# Altium schematic sheet DMotor_Schematic_page2.SchDoc
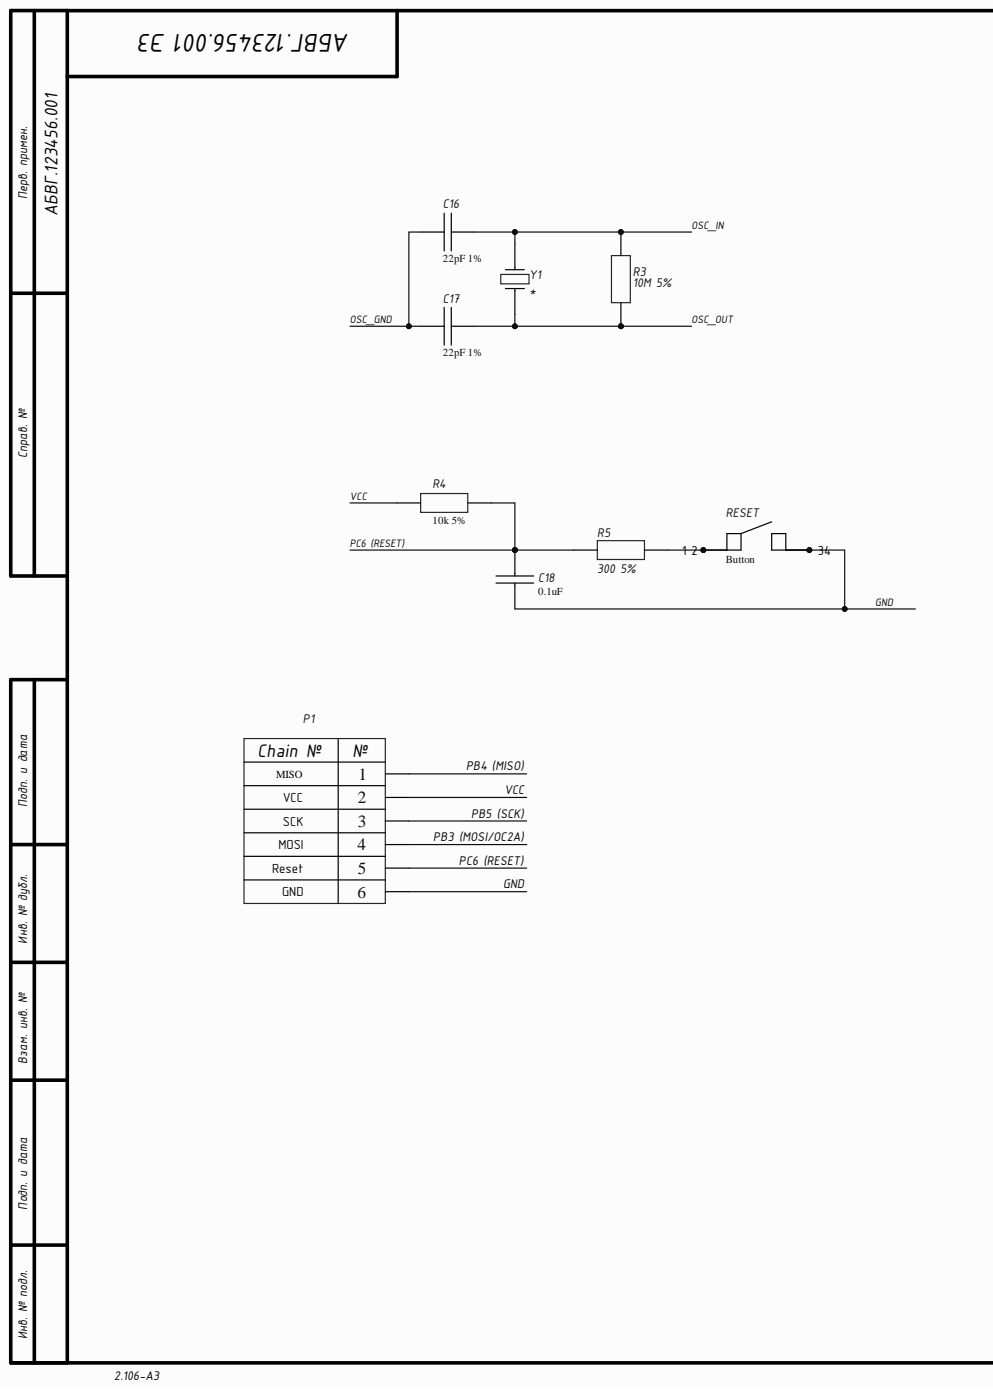
[diagram p0: DMotor_Schematic_page2.SchDoc, left]
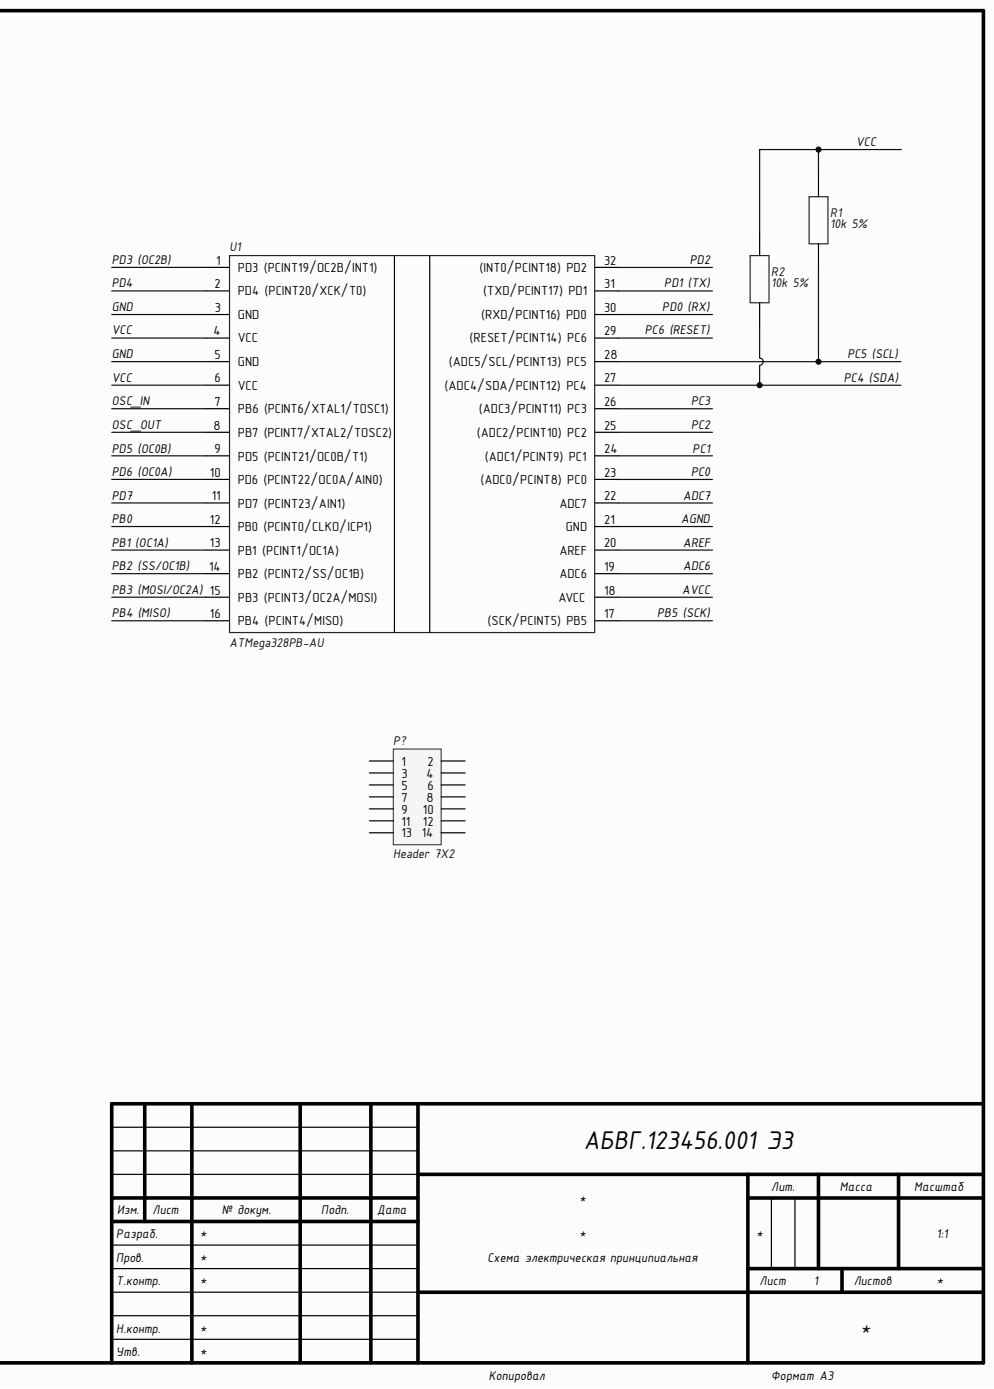
[diagram p1: DMotor_Schematic_page2.SchDoc, right]

## Parts
C16  22pF 1%  [C0603]
C17  22pF 1%  [C0603]
C18  0.1uF  [C0603]
P1  ICSP  [2x3 Male Header Through Hole Pitch 2.54 mm]  (value not drawn)
P?  Header 7X2  [HDR2X7]
R1  10k 5%  [R0603]
R2  10k 5%  [R0603]
R3  10M 5%  [R0603]
R4  10k 5%  [R0603]
R5  300 5%  [R0603]
RESET  Button  [KMR221GLFS]
U1  ATMega328PB-AU  [TQFP-32 (7x7)]
Y1  (no value)  [HC-49S]

## Nets
ADC6: U1.19(ADC6)
ADC7: U1.22(ADC7)
AGND: U1.21(GND)
AREF: U1.20(AREF)
AVCC: U1.18(AVCC)
GND: C18.2 P1.6 RESET.3 RESET.4 U1.3(GND) U1.5(GND)
OSC_GND: C16.1 C17.2
OSC_IN: C16.2 R3.2 U1.7(PB6) Y1.2
OSC_OUT: C17.1 R3.1 U1.8(PB7) Y1.1
PB0: U1.12(PB0)
PB1 (OC1A): U1.13(PB1)
PB2 (SS/OC1B): U1.14(PB2)
PB3 (MOSI/OC2A): P1.4 U1.15(PB3)
PB4 (MISO): P1.1 U1.16(PB4)
PB5 (SCK): P1.3 U1.17(PB5)
PC0: U1.23(PC0)
PC1: U1.24(PC1)
PC2: U1.25(PC2)
PC3: U1.26(PC3)
PC4 (SDA): R2.1 U1.27(PC4)
PC5 (SCL): R1.1 U1.28(PC5)
PC6 (RESET): C18.1 P1.5 R4.2 R5.1 U1.29(PC6)
PD0 (RX): U1.30(PD0)
PD1 (TX): U1.31(PD1)
PD2: U1.32(PD2)
PD3 (OC2B): U1.1(PD3)
PD4: U1.2(PD4)
PD5 (OC0B): U1.9(PD5)
PD6 (OC0A): U1.10(PD6)
PD7: U1.11(PD7)
VCC: P1.2 R1.2 R2.2 R4.1 U1.4(VCC) U1.6(VCC)
NetR5_2: R5.2 RESET.1 RESET.2

Not connected: P? 1 2 3 4 5 6 7 8 9 10 11 12 13 14

## Library data of the parts
C16-C18 = Capasitor: Component Kind: Standard; Library Name: Linalance_lib.IntLib
  C16 C17: Value: 22pF 1%
  C18: Value: 0.1uF
P? = Header 7X2: Header, 7-Pin, Dual row; Component Kind: Standard; LatestRevisionDate: 17-Jul-2002; LatestRevisionNote: Re-released for DXP Platform.; Library Name: Miscellaneous Connectors.IntLib; Signal Integrity: Connector; Publisher: Altium Limited
R1-R5 = Resistor: Component Kind: Standard
  R1 R2: Library Name: Board_lib.IntLib; Value: 10k 5%
  R3: Library Name: Board_lib.IntLib; Value: 10M 5%
  R4: Value: 10k 5%; Library Name: Linalance_lib.IntLib
  R5: Library Name: Board_lib.IntLib; Value: 300 5%
RESET = Button: SWITCH TACTILE SPST-NO 0.05A 32V; Manufacturer: C&K; Case: KMR221GLFS; Component Kind: Standard; HelpURL: https://www.digikey.com/product-detail/en/c-k/KMR221GLFS/401-1427-1-ND/550466; Model: KMR221GLFS; Library Name: Linalance_lib.IntLib
U1 = ATMega328P: AVR AVR® ATmega Microcontroller IC 8-Bit 20MHz 32KB (16K x 16) FLASH 32-TQFP (7x7); Manufacturer: Microchip Technology; Case: TQFP-32; Component Kind: Standard; HelpURL: https://www.digikey.com/product-detail/en/microchip-technology/ATMEGA328PB-AU/ATMEGA328PB-AU-ND/5638812; Library Name: Board_lib.IntLib; Model: ATMega328PB-AU
Y1 = Crystal: Component Kind: Standard; Library Name: Board_lib.IntLib
P1 = ICSP: Component Kind: Standard; Library Name: Board_lib.IntLib
